# Altium schematic sheet main.SchDoc(main)
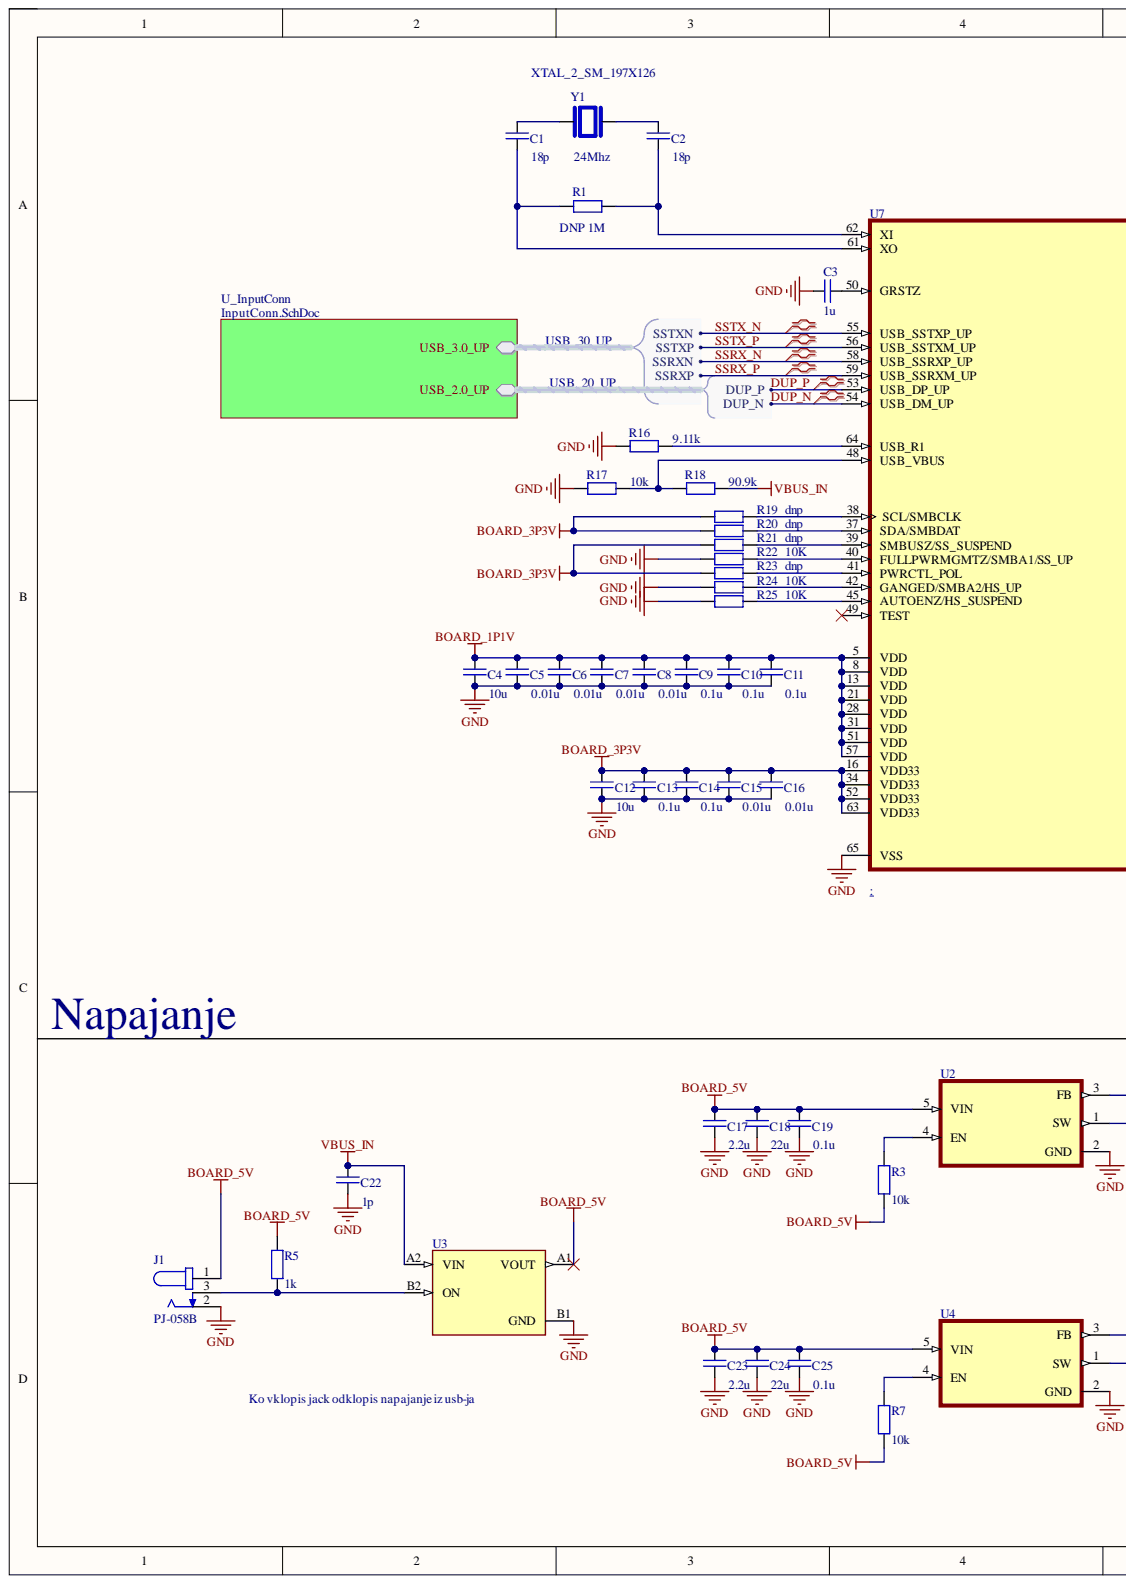
[diagram p0: main.SchDoc, left]
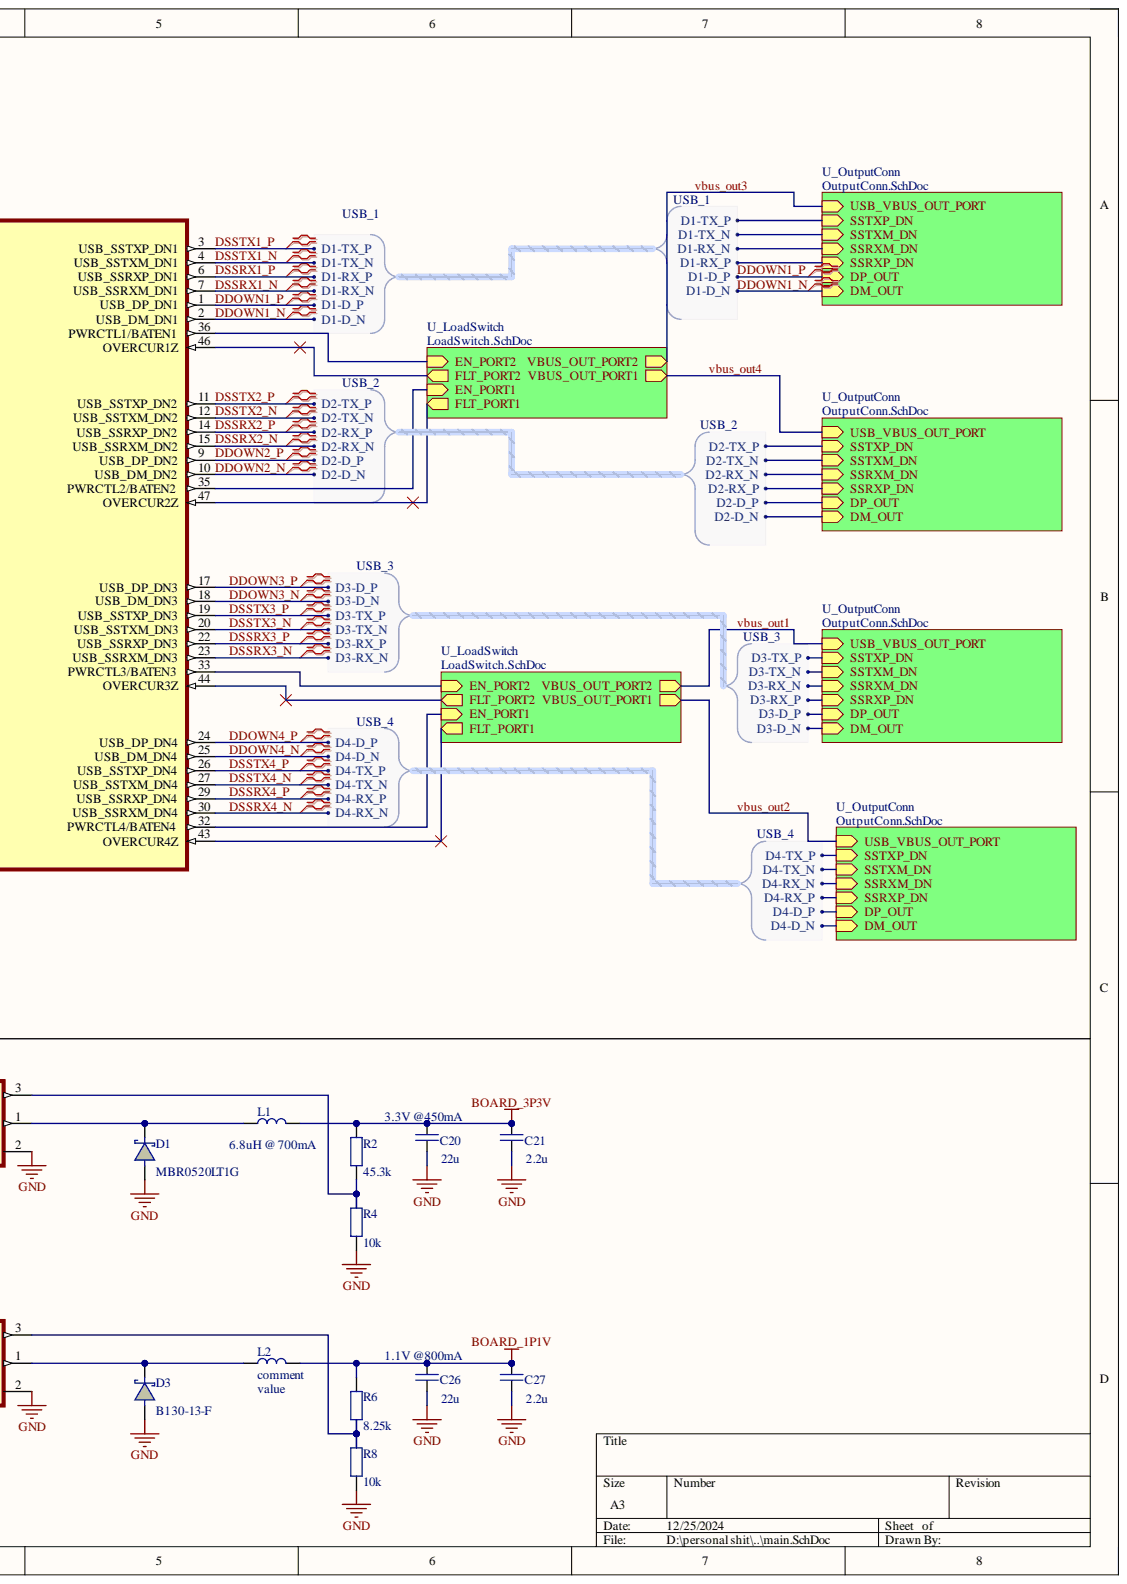
[diagram p1: main.SchDoc, right]

## Parts
C1  value 18p  [CAP_0603_N]  (hidden comment: comment)
C2  value 18p  [CAP_0603_N]  (hidden comment: comment)
C3  value 1u  [CAP_0603_N]  (hidden comment: comment)
C4  value 10u  [CAP_0603_N]  (hidden comment: comment)
C5  value 0.01u  [CAP_0603_N]  (hidden comment: comment)
C6  value 0.01u  [CAP_0603_N]  (hidden comment: comment)
C7  value 0.01u  [CAP_0603_N]  (hidden comment: comment)
C8  value 0.01u  [CAP_0603_N]  (hidden comment: comment)
C9  value 0.1u  [CAP_0603_N]  (hidden comment: comment)
C10  value 0.1u  [CAP_0603_N]  (hidden comment: comment)
C11  value 0.1u  [CAP_0603_N]  (hidden comment: comment)
C12  value 10u  [CAP_0603_N]  (hidden comment: comment)
C13  value 0.1u  [CAP_0603_N]  (hidden comment: comment)
C14  value 0.1u  [CAP_0603_N]  (hidden comment: comment)
C15  value 0.01u  [CAP_0603_N]  (hidden comment: comment)
C16  value 0.01u  [CAP_0603_N]  (hidden comment: comment)
C17  value 2.2u  [CAP_0603_N]  (hidden comment: comment)
C18  value 22u  [CAP_0603_N]  (hidden comment: comment)
C19  value 0.1u  [CAP_0603_N]  (hidden comment: comment)
C20  value 22u  [CAP_0603_N]  (hidden comment: comment)
C21  value 2.2u  [CAP_0603_N]  (hidden comment: comment)
C22  value 1p  [CAP_0603_N]  (hidden comment: comment)
C23  value 2.2u  [CAP_0603_N]  (hidden comment: comment)
C24  value 22u  [CAP_0603_N]  (hidden comment: comment)
C25  value 0.1u  [CAP_0603_N]  (hidden comment: comment)
C26  value 22u  [CAP_0603_N]  (hidden comment: comment)
C27  value 2.2u  [CAP_0603_N]  (hidden comment: comment)
D1  value MBR0520LT1G  [SOD-123_N]  (hidden comment: comment)
D3  value B130-13-F  [SOD-123_N]  (hidden comment: comment)
J1  PJ-058B  [CUI_PJ-058B]
L1  value 6.8uH @ 700mA  [SMD_1206_N]  (hidden comment: comment)
L2  comment  [SMD_0603_N]
R1  DNP  value 1M  [SMD_0603_N]
R2  value 45.3k  [SMD_0603_N]  (hidden comment: comment)
R3  value 10k  [SMD_0603_N]  (hidden comment: comment)
R4  value 10k  [SMD_0603_N]  (hidden comment: comment)
R5  value 1k  [SMD_0603_N]  (hidden comment: comment)
R6  value 8.25k  [SMD_0603_N]  (hidden comment: comment)
R7  value 10k  [SMD_0603_N]  (hidden comment: comment)
R8  value 10k  [SMD_0603_N]  (hidden comment: comment)
R16  value 9.11k  [SMD_0603_N]  (hidden comment: DNP)
R17  value 10k  [SMD_0603_N]  (hidden comment: DNP)
R18  value 90.9k  [SMD_0603_N]  (hidden comment: DNP)
R19  DNP  [SMD_0603_N]
R20  DNP  [SMD_0603_N]
R21  DNP  [SMD_0603_N]
R22  value 10K  [SMD_0603_N]  (hidden comment: DNP)
R23  DNP  [SMD_0603_N]
R24  value 10K  [SMD_0603_N]  (hidden comment: DNP)
R25  value 10K  [SMD_0603_N]  (hidden comment: DNP)
U2  (no value)  [SOT95P280X145-5N]
U3  (no value)  [BGA4N50P2X2_88X88X50N]
U4  (no value)  [SOT95P280X145-5N]
U7  (no value)  [QFN50P900X900X100-65N]
Y1  XTAL_2_SM_197X126  value 24Mhz  [XTAL_CC7V-T1A-32.768KHZ-12.5PF-100PPM-TA-QC]

## Nets
BOARD_1P1V: C4.1 C5.1 C6.1 C7.1 C8.1 C9.1 C10.1 C11.1 C26.1 C27.1 L2.2 R6.1 U7.5(VDD) U7.8(VDD) U7.13(VDD) U7.21(VDD) U7.28(VDD) U7.31(VDD) U7.51(VDD) U7.57(VDD)
BOARD_3P3V: C12.1 C13.1 C14.1 C15.1 C16.1 C20.1 C21.1 L1.2 R2.1 R19.1 R20.1 R21.1 R23.1 U7.16(VDD33) U7.34(VDD33) U7.52(VDD33) U7.63(VDD33)
BOARD_5V: C17.1 C18.1 C19.1 C23.1 C24.1 C25.1 J1.1 R3.2 R5.1 R7.2 U2.5(VIN) U3.A1(VOUT) U4.5(VIN)
GND: C3.1 C4.2 C5.2 C6.2 C7.2 C8.2 C9.2 C10.2 C11.2 C12.2 C13.2 C14.2 C15.2 C16.2 C17.2 C18.2 C19.2 C20.2 C21.2 C22.2 C23.2 C24.2 C25.2 C26.2 C27.2 D1.A D3.A J1.2 R4.2 R8.2 R16.1 R17.1 R22.1 R24.1 R25.1 U2.2(GND) U3.B1(GND) U4.2(GND) U7.65(VSS)
VBUS_IN: C22.1 R18.2 U3.A2(VIN)
DDOWN1_N: U7.2(USB_DM_DN1)
DDOWN1_P: U7.1(USB_DP_DN1)
DDOWN2_N: U7.10(USB_DM_DN2)
DDOWN2_P: U7.9(USB_DP_DN2)
DDOWN3_N: U7.18(USB_DM_DN3)
DDOWN3_P: U7.17(USB_DP_DN3)
DDOWN4_N: U7.25(USB_DM_DN4)
DDOWN4_P: U7.24(USB_DP_DN4)
DSSRX1_N: U7.7(USB_SSRXM_DN1)
DSSRX1_P: U7.6(USB_SSRXP_DN1)
DSSRX2_N: U7.15(USB_SSRXM_DN2)
DSSRX2_P: U7.14(USB_SSRXP_DN2)
DSSRX3_N: U7.23(USB_SSRXM_DN3)
DSSRX3_P: U7.22(USB_SSRXP_DN3)
DSSRX4_N: U7.30(USB_SSRXM_DN4)
DSSRX4_P: U7.29(USB_SSRXP_DN4)
DSSTX1_N: U7.4(USB_SSTXM_DN1)
DSSTX1_P: U7.3(USB_SSTXP_DN1)
DSSTX2_N: U7.12(USB_SSTXM_DN2)
DSSTX2_P: U7.11(USB_SSTXP_DN2)
DSSTX3_N: U7.20(USB_SSTXM_DN3)
DSSTX3_P: U7.19(USB_SSTXP_DN3)
DSSTX4_N: U7.27(USB_SSTXM_DN4)
DSSTX4_P: U7.26(USB_SSTXP_DN4)
DUP_N: U7.54(USB_DM_UP)
DUP_P: U7.53(USB_DP_UP)
SSRX_N: U7.58(USB_SSRXP_UP)
SSRX_P: U7.59(USB_SSRXM_UP)
SSTX_N: U7.55(USB_SSTXP_UP)
SSTX_P: U7.56(USB_SSTXM_UP)
NetC1_1: C1.1 Y1.1
NetC1_2: C1.2 R1.1 U7.61(XO)
NetC2_1: C2.1 Y1.2
NetC2_2: C2.2 R1.2 U7.62(XI)
NetC3_2: C3.2 U7.50(GRSTZ)
NetD1_C: D1.C L1.1 U2.1(SW)
NetD3_C: D3.C L2.1 U4.1(SW)
NetJ1_3: J1.3 R5.2 U3.B2(ON)
NetR2_2: R2.2 R4.1 U2.3(FB)
NetR3_1: R3.1 U2.4(EN)
NetR6_2: R6.2 R8.1 U4.3(FB)
NetR7_1: R7.1 U4.4(EN)
NetR16_2: R16.2 U7.64(USB_R1)
NetR17_2: R17.2 R18.1 U7.48(USB_VBUS)
NetR19_2: R19.2 U7.38(SCL/SMBCLK)
NetR20_2: R20.2 U7.37(SDA/SMBDAT)
NetR21_2: R21.2 U7.39(SMBUSZ/SS_SUSPEND)
NetR22_2: R22.2 U7.40(FULLPWRMGMTZ/SMBA1/SS_UP)
NetR23_2: R23.2 U7.41(PWRCTL_POL)
NetR24_2: R24.2 U7.42(GANGED/SMBA2/HS_UP)
NetR25_2: R25.2 U7.45(AUTOENZ/HS_SUSPEND)
NetU7_32: U7.32(PWRCTL4/BATEN4)
NetU7_33: U7.33(PWRCTL3/BATEN3)
NetU7_35: U7.35(PWRCTL2/BATEN2)
NetU7_36: U7.36(PWRCTL1/BATEN1)
NetU7_43: U7.43(OVERCUR4Z)
NetU7_44: U7.44(OVERCUR3Z)
NetU7_46: U7.46(OVERCUR1Z)
NetU7_47: U7.47(OVERCUR2Z)
NetU7_49: U7.49(TEST)
vbus_out1:
vbus_out2:
vbus_out3:
vbus_out4:

## Library data of the parts
C1-C27 = Capacitor non-polarized: Component Kind: Standard; Library Name: USB-HUB1.IntLib
D1 D3 = Diode Schottky: Component Kind: Standard; Library Name: USB-HUB1.IntLib
J1 = PJ-058B: 2.5 mm Center Pin, 2.5 A, Right Angle, Through Hole, Locking Type, Dc Power Jack Connector; Availability: Unavailable; Component Kind: Standard; Library Name: USB-HUB.IntLib; MP: PJ-058B; Package: None; Price: None
L1 L2 = Inductor: Component Kind: Standard; Library Name: USB-HUB1.IntLib
  L2: Value: value
R1-R8 R16-R25 = Resistor: Component Kind: Standard; Library Name: USB-HUB1.IntLib
  R19-R21 R23: Value: dnp
U2 U4 = LMR10510XMFX/NOPB: Availability: Unavailable; Component Kind: Standard; Library Name: USB-HUB1.IntLib; MP: LMR10510XMFX/NOPB; Package: None; Price: None
U3 = TPS22910AYZVR: 5.5V, 2A, 61m? Active-Low Load Switch With Reverse Current Protection; Availability: Unavailable; Component Kind: Standard; Library Name: USB-HUB1.IntLib; MP: TPS22910AYZVR; Package: None; Price: None
U7 = TUSB8041RGCR: 4-port 5-Gbps SuperSpeed USB 3.0 hub 64-VQFN 0 to 70; MF: Texas Instruments; Arrow_Electronics-Purchase-URL: https://snapeda.com/shop?store=Arrow+Electronics&id=104660; Availability: Good; Component Kind: Standard; Library Name: USB-HUB1.IntLib; Mouser-Purchase-URL: https://snapeda.com/shop?store=Mouser&id=104660; MP: TUSB8041RGCR; Package: VQFN-64 Texas Instruments; Price: None; SnapEDA_Link: https://www.snapeda.com/parts/TUSB8041RGCR/Texas%20Instruments/view-part/104660/?ref=snap; Texas_Instruments-Purchase-URL: https://snapeda.com/shop?store=Texas+Instruments&id=104660
Y1 = CC7V-T1A-32.768KHZ-12.5PF-100PPM-TA-QC: LOW FREQUENCY CRYSTAL; MF: Micro Crystal AG; Availability: In Stock; Check_prices: https://www.snapeda.com/parts/CC7V-T1A-32.768KHZ-12.5PF-100PPM-TA-QC/Micro+Crystal/view-part/?ref=eda; Component Kind: Standard; Library Name: CC7V-T1A-32.768KHZ-12.5PF-100PPM-TA-QC.IntLib; MP: CC7V-T1A-32.768KHZ-12.5PF-100PPM-TA-QC; Package: SMD-2 Micro Crystal; Price: None; Purchase-URL: https://pricing.snapeda.com/search/part/CC7V-T1A-32.768KHZ-12.5PF-100PPM-TA-QC/?ref=eda; SnapEDA_Link: https://www.snapeda.com/parts/CC7V-T1A-32.768KHZ-12.5PF-100PPM-TA-QC/Micro+Crystal/view-part/?ref=snap
